# Altium schematic sheet 1. Power supply.SchDoc("1. Power supply")
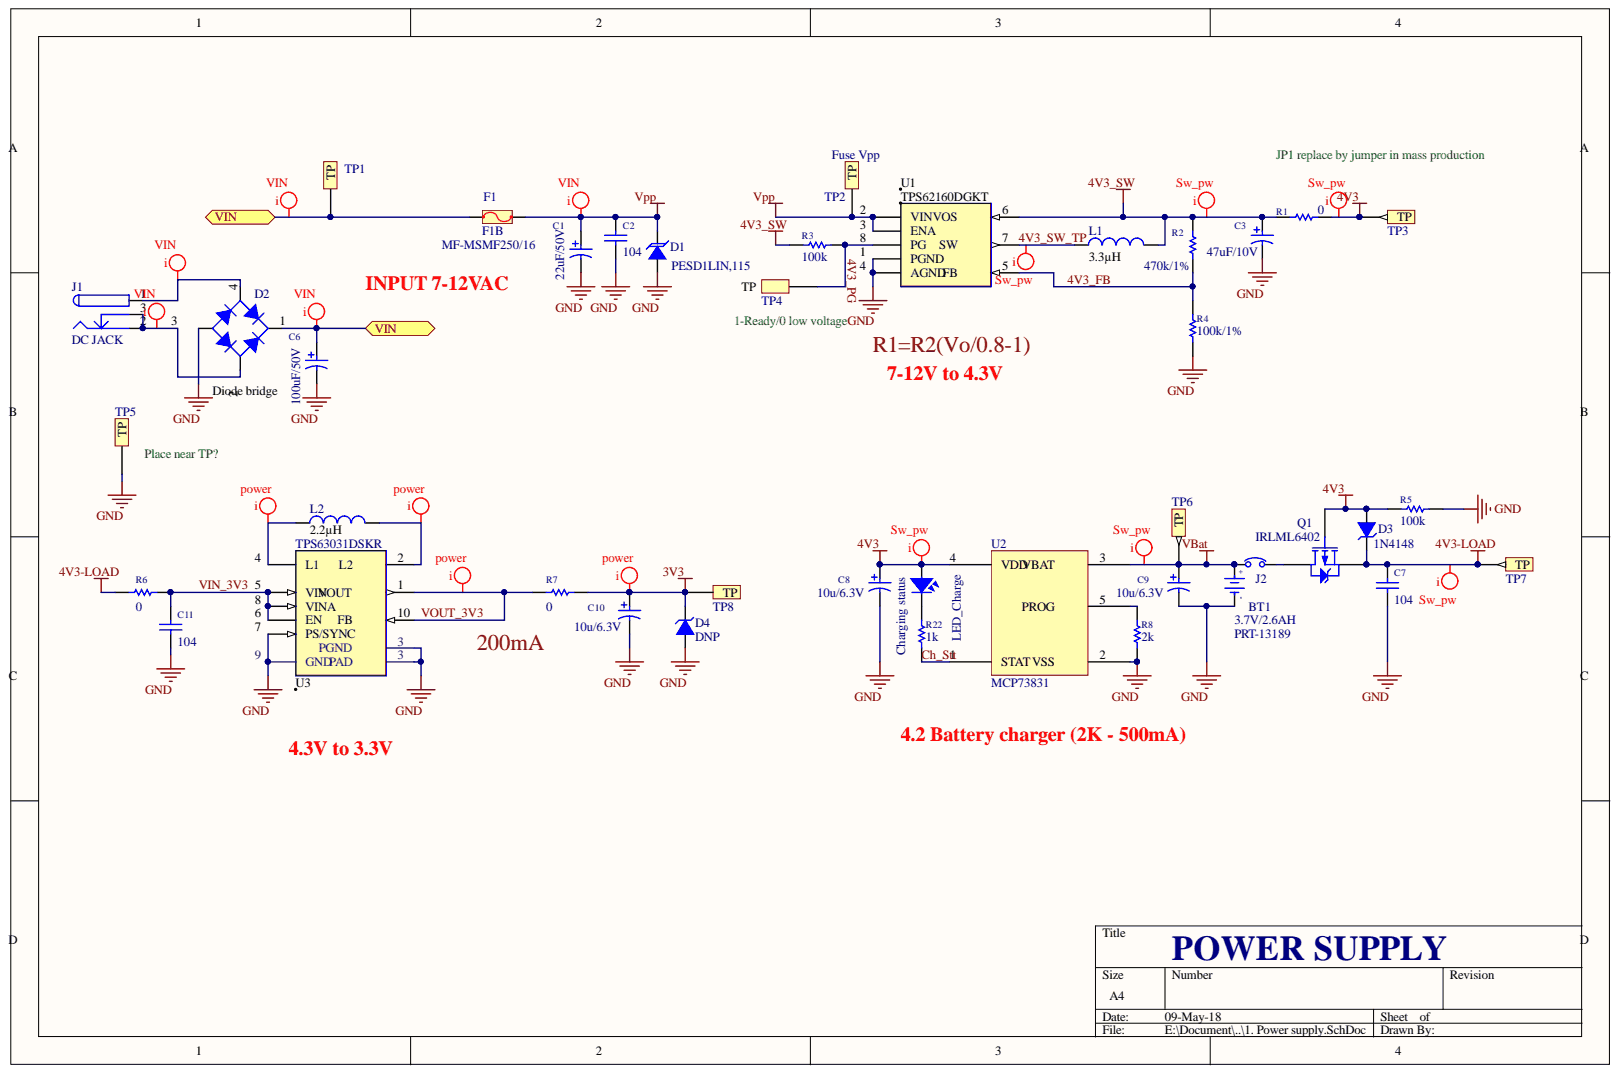
[diagram p0: 1. Power supply.SchDoc]

## Parts
BT1  3.7V/2.6AH  value PRT-13189  Manufacturer P/N: PRT-13189  [Batterry Cell]
C1  value 22uF/50V  [CAP_SMD_6_3MM_N]  (hidden comment: 22uu/50V)
C2  104  [sm-0603-c]
C3  47uF  value 47uF/10V  [Cap SMD Tant - 470u]
C6  value 100uF/50V  [CAP SMD ALUMINUM G.CAOVANHUONG]  (hidden comment: 22uu/50V)
C7  104  [sm-0603-c]
C8  10u  value 10u/6.3V  [Cap SMD Tant 10u]
C9  10u  value 10u/6.3V  [Cap SMD Tant 10u]
C10  10u  value 10u/6.3V  [Cap SMD Tant 10u]
C11  104  [sm-0603-c]
D1  PESD1LIN,115  [DIODE_SMB]
D2  Diode Bridge  [DB-38R]
D3  1N4148  [DIODE-SOD80]
D4  DNP  [DIODE-SOD80]
F1  F1B  value MF-MSMF250/16  Manufacturer P/N: MF-MSMF250/16  [SMD-PTC]
J1  DC JACK  [DC JACK.CAOVANHUONG]
J2  jumper selector  [CON.HDR1X2]  (value not drawn)
L1  3.3µH  [Inductor 2]
L2  2.2µH  [INDUCTOR 4]
LED_Charge  Charging status  [LED0603]
Q1  IRLML6402  [SOT23-3.CAOVANHUONG]
R1  0  [R2012-0805]
R2  470k  value 470k/1%  [SM-0603-R]
R3  100k  [SM-0603-R]
R4  100k  value 100k/1%  [SM-0603-R]
R5  100k  [SM-0603-R]
R6  0  [R2012-0805]
R7  0  [R2012-0805]
R8  value 2k  [SM-0603-R]  (hidden comment: 100k)
R22  1k  [SM-0603-R]
TP1  Vin  [TP]  (value not drawn)  (no BOM)
TP2  VIN  [TP]  (value not drawn)  (no BOM)
TP3  4V3  [TP]  (no BOM)
TP4  4V3_PG  [TP]  (no BOM)
TP5  GND  [TP]  (no BOM)
TP6  4V3  [TP]  (value not drawn)  (no BOM)
TP7  4V3  [TP]  (no BOM)
TP8  TP  [TP]  (no BOM)
U1  TPS62160DGKT  [SSOP8.S-PDSO-G8]
U2  MCP73831  [SOT23-5.CAOVANHUONG]
U3  TPS63031DSKR  [WSON10]

## Ports
VIN

## Nets
3V3: C10.1 D4.2 R7.2 TP8.1
4V3: C8.1 D3.1 LED_Charge.1 Q1.1 R1.2 R5.1 TP3.1 U2.4(VDD)
4V3-LOAD: C7.1 D3.2 Q1.2 R6.1 TP7.1
4V3_SW: C3.1 L1.2 R1.1 R2.1 R3.2 U1.6
GND: BT1.2 C1.2 C2.2 C3.2 C6.2 C7.2 C8.2 C9.2 C10.2 C11.2 D1.1 D2.3 D4.1 R4.2 R5.2 R8.2 TP5.1 U1.1(PGND) U1.4(AGND) U2.2 U3.3 U3.7(PS/SYNC) U3.9(GND)
VBAT: BT1.1 C9.1 J2.1 TP6.1 U2.3
VPP: C1.1 C2.1 D1.2 F1.2 TP2.1 U1.2(VIN_VOS) U1.3(ENA)
4V3_FB: R2.2 R4.1 U1.5
4V3_PG: R3.1 TP4.1 U1.8(PG)
4V3_SW_TP: L1.1 U1.7
CH_STT: R22.1 U2.1
VIN: C6.1 D2.1 F1.1 TP1.1 ; port VIN
VIN_3V3: C11.1 R6.2 U3.5(VIN) U3.6(EN) U3.8(VINA)
VOUT_3V3: R7.1 U3.1 U3.10
NetD2_2: D2.2 J1.2 J1.3
NetD2_4: D2.4 J1.1
NetJ2_2: J2.2 Q1.3
NetL2_1: L2.1 U3.4(L1)
NetL2_2: L2.2 U3.2
NetLED_Charge_2: LED_Charge.2 R22.2
NetR8_1: R8.1 U2.5

## Library data of the parts
BT1 = PIN 3V: BATTERY LITHIUM 3.7V 2.6AH; Manufacturer: SparkFun Electronics; Vendor: DIGIKEY; Vendor P/N: 1568-1490-ND; Component Kind: Standard; Library Name: LIB_MISCELLANEOUS.SchLib; Package: 0.72" Dia x 2.56" H; Part: PRT-13189
C1 C6 = Cap_Pol: CAP ALUM 22UF 20% 50V SMD; Manufacturer: Panasonic Electronic Components; Manufacturer P/N: EEE-FK1H220P; Part: 22uuF; Type: Aluminum Solid/Tantalum Capacitor; Vendor: DIGIKEY; Vendor P/N: PCE3811CT-ND; Component Kind: Standard; Library Name: My_Integrated_Library.IntLib; Tolerence: 20%; Voltage: 50V
C2 C7 C11 = Capacitor: CAP CER 10000PF 16V 0603; Manufacturer: Taiyo Yuden; Manufacturer P/N: EMK107SD103JA-T; Vendor: DIGIKEY; Vendor P/N: 587-1093-1-ND; Component Kind: Standard; Library Name: My_Integrated_Library.IntLib; Package: 0603; Part: EMK107SD103JA-T; PCB3D: PolySwitch2; Value: 104; Voltage: 16V
C3 = Cap_Pol: 47uF/10V ±10% SMD Tantalum Capacitor; Manufacturer: AVX; Manufacturer P/N: TAJC476K010RNJ; Type: Aluminum Solid/Tantalum Capacitor; Voltage: 10V; Component Kind: Standard; Library Name: My_Integrated_Library.IntLib; Package: 0603; Part: 47uF; Price: 1600 VND; Vendor: www.tme.vn; Vendor P/N: TAJC476K010RNJ
C8-C10 = Cap_Pol: CAP CER 10UF 6.3V X5R 1206; Manufacturer: Samsung Electro-Mechanics; Manufacturer P/N: CL31A106MQHNNNE; Tollerance: 20%; Vendor: DIGIKEY; Vendor P/N: 1276-3045-1-ND; Component Kind: Standard; Library Name: My_Integrated_Library.IntLib; Package: 1206; Part: 10u; Voltage: 6.3V
D1 = Diode TVS: TVS DIODE 15V/24V 44V/70V SOD323; Manufacturer: Nexperia USA Inc.; Current: 3A; Power: 160W; Vendor: DIGIKEY; Vendor P/N: 1727-3818-1-ND; Voltage: 15V; Component Kind: Standard; Library Name: LIB_MISCELLANEOUS.SchLib; Manufacturer P/N: PESD1LIN,115; Package: SOD323; Part: PESD1LIN,115; Value: PESD1LIN,115
D2 = Diode_Bridge: Rectifier Bridge; Vendor: Digikey; Component Kind: Standard; ComponentLink1Description: Digikey Part Link; ComponentLink1URL: http://www.irf.com/; ComponentLink2Description: Open Datasheet; ComponentLink2URL: http://www.irf.com/product-info/datasheets/data/1kab-e.pdf; Date of Price: 19/11/2010; LatestRevisionDate: 17-Jul-2002; LatestRevisionNote: Re-released for DXP Platform.; Library Name: My_Integrated_Library.IntLib; PackageReference: D-38; Part: Diode_Bridge; Price: 2000 VND; Published: 8-Jun-2000; VALUE: Diode bridge
D3 D4 = Diode Zener: Zener Diode; Current: Unknow; Value: Unknow; Component Kind: Standard; DNP: DNP; Library Name: LIB_MISCELLANEOUS.SchLib; Manufacturer: Unknow; Manufacturer P/N: Unknow; Package: Unknow; Part: Unknow; Price: Unknow; Vendor: Unknow; Vendor P/N: Unknow; Voltage: Unknow
F1 = Fuse: 2.5A/16V SMD-1812 PTC Resettable Fuses; Manufacturer: Bourns Inc.; Component Kind: Standard; Library Name: LIB_MISCELLANEOUS.SchLib; Package: 1812; Part: MF-MSMF250/16; Vendor: www.tme.vn; Vendor P/N: MF-MSMF250/16
J1 = DC JACK: Low Voltage Power Supply Connector; Component Kind: Standard; ComponentLink1Description: Manufacturer Link; ComponentLink1URL: http://domino.kycon.com/internet/Products.html; DatasheetDocument: 09-May-2002; Library Name: caovanhuong.intlib; Published: 17-Dec-2003; Publisher: Altium Limited
L1 = Inductor: FIXED IND 3.3UH 3.2A 30.2 MOHM; Manufacturer: Bourns Inc.; Manufacturer P/N: SRN6045-3R3Y; Tollerance: 30%; Vendor: DIGIKEY; Vendor P/N: SRN6045-3R3YCT-ND; Component Kind: Standard; Current: 3.2A; Library Name: My_Integrated_Library.IntLib; Package: 6.00mm x 6.00mm; Part: 3.3µH; Res: 30.2 mOhm; Value: 3.3µH
L2 = Inductor: FIXED IND 2.2UH 2.9A 42 MOHM SMD; Manufacturer: Taiyo Yuden; Manufacturer P/N: NRS5020T2R2NMGJ; Res: 42 mOhm; Tollerance: 30%; Vendor: DIGIKEY; Vendor P/N: 587-2405-1-ND; Component Kind: Standard; Current: 2.9A; Library Name: My_Integrated_Library.IntLib; Package: 4.90mm x 4.90mm; Part: 2.2µH; Value: 2.2µH
LED_Charge = LED: LED Single colour Green and Red; Current: Unknow; Value: LED 3 ly Single Colour; Component Kind: Standard; DNP: DNP; Library Name: LIB_MISCELLANEOUS.SchLib; Manufacturer: Unknow; Manufacturer P/N: Unknow; Package: Unknow; Part: Unknow; Price: Unknow; Vendor: Unknow; Vendor P/N: Unknow; Voltage: Unknow
Q1 = IRLML6402: P-Channel MOSFET, ID =3.7A @ TA = 25°C, VGS @ -4.5V; Component Kind: Standard; LatestRevisionDate: 17-Jul-2002; LatestRevisionNote: Re-released for DXP Platform.; Library Name: caovanhuong.intlib; PackageDescription: TO, Flat Index; 3 In-Line, Axial Leads; Body Dia. 4.6mm; Lead Dia. 0.5 mm (max); PackageReference: E3; PackageVersion: 1995/1996; Published: 8-Jun-2000; Publisher: Altium Limited
R1 R6 R7 = Resistor: RES SMD 0 OHM JUMPER 1/10W 0805; Manufacturer: Panasonic Electronic Components; Manufacturer P/N: ERJ-6GEY0R00V; Tollerance: 5%; Vendor: DIGIKEY; Vendor P/N: P0.0ACT-ND; Component Kind: Standard; Library Name: My_Integrated_Library.IntLib; Package: 0805; Part: 0; Power: 1/10W; Value: 0
R2 = Resistor: RES SMD 470K OHM 1% 1/10W 0603; Manufacturer: Panasonic Electronic Components; Manufacturer P/N: ERJ-3EKF4703V; Vendor: DIGIKEY; Vendor P/N: P470KHCT-ND; Component Kind: Standard; Library Name: My_Integrated_Library.IntLib; Package: 0603; Part: 470k; Power: 1/10W; Tollerance: 1%
R3 R5 R8 = Resistor: RES SMD 100K OHM 5% 1/10W 0603; Manufacturer: Panasonic Electronic Components; Manufacturer P/N: ERJ-3GEYJ104V; Vendor: DIGIKEY; Vendor P/N: P100KGCT-ND; Component Kind: Standard; Library Name: My_Integrated_Library.IntLib; Package: 0603; Part: 100k; Power: 1/10W; Tollerance: 5%
  R3 R5: Value: 100k
R4 = Resistor: RES SMD 100K OHM 1% 1/10W 0603; Manufacturer: Panasonic Electronic Components; Manufacturer P/N: ERJ-3EKF1003V; Vendor: DIGIKEY; Vendor P/N: P100KHCT-ND; Component Kind: Standard; Library Name: My_Integrated_Library.IntLib; Package: 0603; Part: 100k; Power: 1/10W; Tollerance: 1%
R22 = Resistor: RES SMD 1K OHM 5% 1/10W 0603; Manufacturer: Panasonic Electronic Components; Manufacturer P/N: ERJ-3GEYJ102V; Vendor: DIGIKEY; Vendor P/N: P1.0KGCT-ND; Component Kind: Standard; Library Name: My_Integrated_Library.IntLib; Package: 0603; Part: 1k; Power: 1/10W; Tollerance: 5%; Value: 1k
TP1-TP8 = TP: Test Point; Library Name: My_Integrated_Library.IntLib
U1 = TPS62160: IC REG BUCK ADJ 1A SYNC 8VSSOP; Manufacturer: Texas Instruments; Vendor: DIGIKEY; Vendor P/N: 296-30318-1-ND; Component Kind: Standard; Library Name: My_Integrated_Library.IntLib; Manufacturer P/N: TPS62160DGKT; Package: VSSOP8; Part: TPS62160DGKT; Value: TPS62160DGKT
U2 = MCP73831: Miniature Single Cell, Fully Integrated Li-Ion,  Li-Polymer Charge Management ControllerController; Manufacturer: Microchip; Vendor: TME; Vendor P/N: MCP73832T-2ACI/OT; Component Kind: Standard; Library Name: caovanhuong.intlib; Package: SOT-23-5; Part: MCP73831; Price: 15.000d
U3 = TPS63031: IC REG BUCK BST 3.3V 0.9A 10SON; Manufacturer: Texas Instruments; Vendor: DIGIKEY; Vendor P/N: 296-39461-1-ND; Component Kind: Standard; Library Name: My_Integrated_Library.IntLib; Manufacturer P/N: TPS63031DSKR; Package: SON10; Part: TPS63031DSKR; Value: TPS63031DSKR
J2 = jumper selector: Component Kind: Standard; ComponentLink2Description: Open Datasheet; Date of Price: 19/11/2010; Library Name: My_Integrated_Library.IntLib; Part: jumper selector; Price: 500 VND
